# Altium schematic sheet USB to RS485 ISO - ISO3088.SchDoc("USB to RS485 ISO - ISO3088")
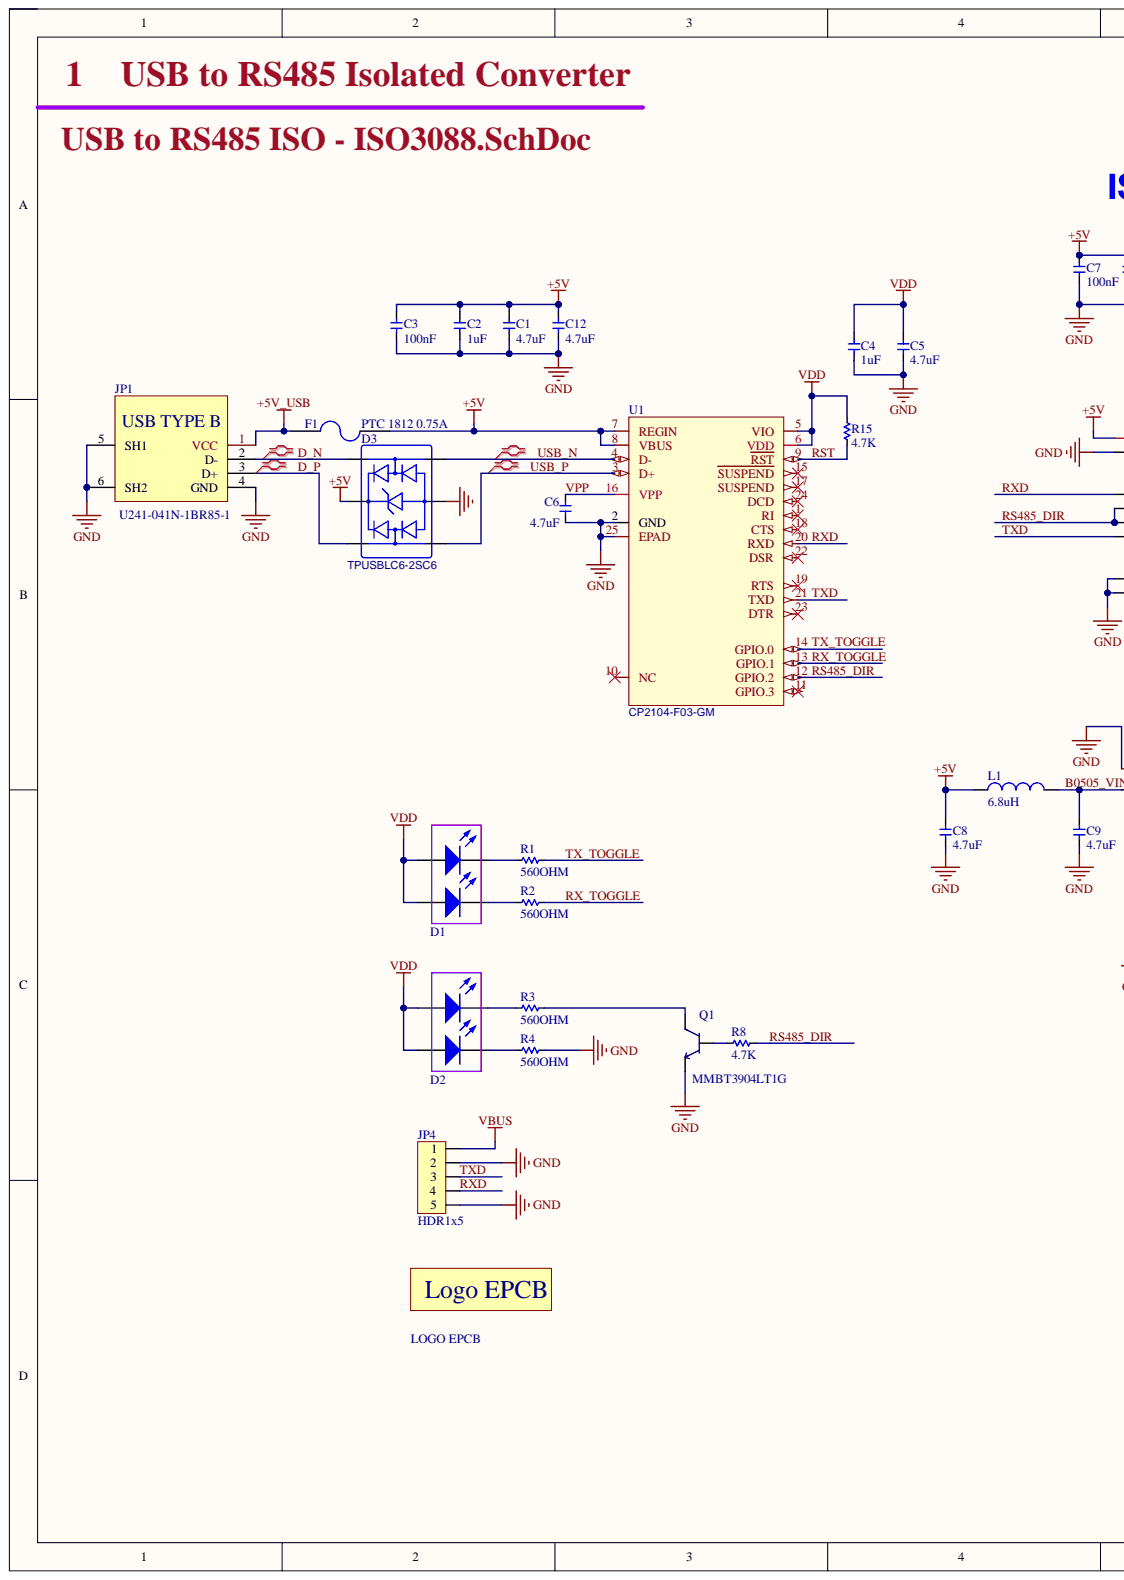
[diagram p0: USB to RS485 ISO - ISO3088.SchDoc, left]
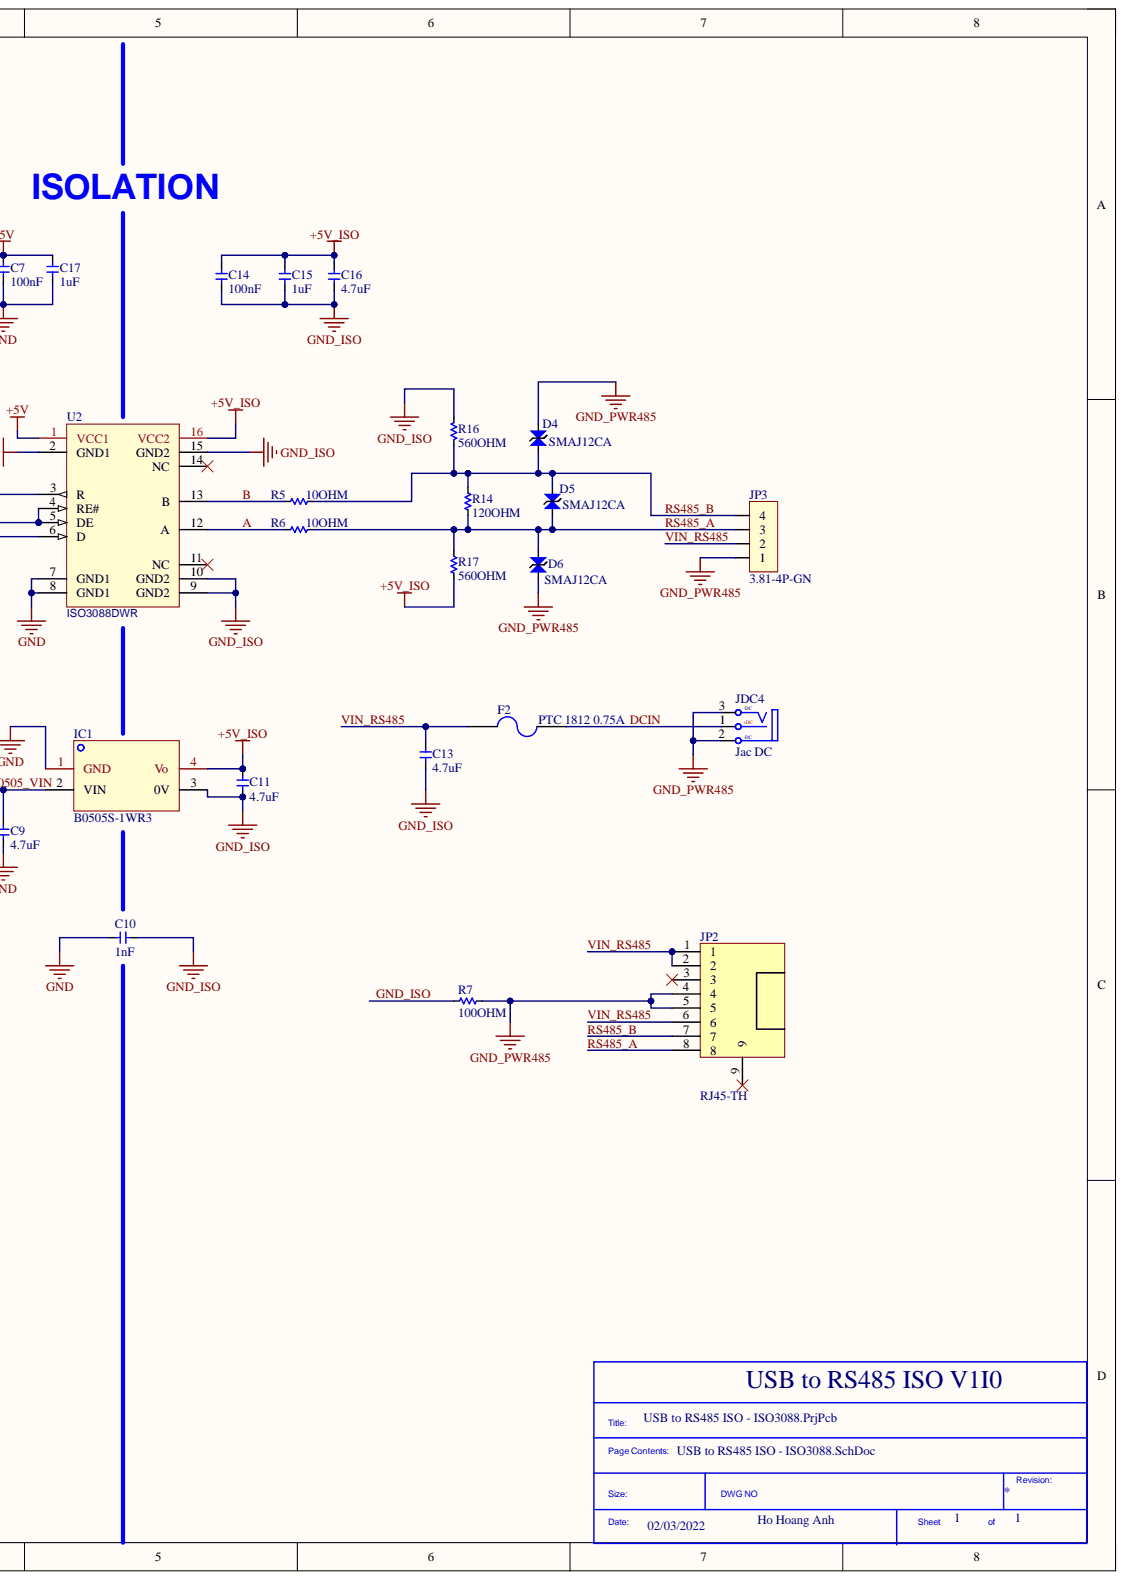
[diagram p1: USB to RS485 ISO - ISO3088.SchDoc, right]

## Parts
C1  4.7uF  [C_1206]
C2  1uF  [C_0603]
C3  100nF  [C_0603]
C4  1uF  [C_0603]
C5  4.7uF  [C_1206]
C6  4.7uF  [C_0603]
C7  100nF  [C_0603]
C8  4.7uF  [C_1206]
C9  4.7uF  [C_1206]
C10  1nF  [C_1206]
C11  4.7uF  [C_1206]
C12  4.7uF  [C_1206]
C13  4.7uF  [C_1206]
C14  100nF  [C_0603]
C15  1uF  [C_0603]
C16  4.7uF  [C_1206]
C17  1uF  [C_0603]
D1  2LED_3MM  [2LED_3MM]  (value not drawn)
D2  2LED_3MM  [2LED_3MM]  (value not drawn)
D3  TPUSBLC6-2SC6  [SOT-23-6_L2.9-W1.6-P0.95-LS2.8-BR]
D4  SMAJ12CA  [SMA_L4.4-W2.6-LS5.0-BI]
D5  SMAJ12CA  [SMA_L4.4-W2.6-LS5.0-BI]
D6  SMAJ12CA  [SMA_L4.4-W2.6-LS5.0-BI]
F1  PTC 1812 0.75A  Package: 1812  [F_1812]
F2  PTC 1812 0.75A  Package: 1812  [F_1812]
IC1  B0505S-1WR3  [PWRM-TH_BXXXXS-1WR3]
JDC4  Jac DC  [DC0]
JP1  U241-041N-1BR85-1  [USB-B-TH_U241-041N-1BR85-1]
JP2  RJ45-TH  [RJ45R10P-B000]
JP3  3.81-4P-GN  [Terminal plug 3.81_4P]
JP4  HDR1x5  [HDR1X5]  (no BOM)
L1  6.8uH  [IND-SMD_L3.0-W3.0]
LOGO_EPCB  LOGO EPCB  [LOGO EPCB]  (no BOM)
Q1  MMBT3904LT1G  [SOT-23-3]
R1  560OHM  [R_0603]
R2  560OHM  [R_0603]
R3  560OHM  [R_0603]
R4  560OHM  [R_0603]
R5  10OHM  [R_0805]
R6  10OHM  [R_0805]
R7  100OHM  [R_0805]
R8  4.7K  [R_0603]
R14  120OHM  [R_0603]
R15  4.7K  [R_0603]
R16  560OHM  [R_0603]
R17  560OHM  [R_0603]
U1  CP2104-F03-GM  [QFN-24_L4.0-W4.0-P0.50-BL-EP2.8]
U2  ISO3088DWR  [SOIC-16_L10.3-W7.5-P1.27-LS10.3-BL]

## Nets
+5V: C1.2 C2.2 C3.2 C7.2 C8.2 C12.2 C17.2 D3.5 F1.2 L1.1 U1.7(REGIN) U1.8(VBUS) U2.1(VCC1)
+5V_ISO: C11.2 C14.2 C15.2 C16.2 IC1.4(Vo) R17.2 U2.16(VCC2)
+5V_USB: F1.1 JP1.1(VCC)
GND: C1.1 C2.1 C3.1 C4.1 C5.1 C6.1 C7.1 C8.1 C9.1 C10.1 C12.1 C17.1 D3.2 IC1.1(GND) JP1.4(GND) JP1.5(SH1) JP1.6(SH2) JP4.2 JP4.5 Q1.2 R4.1 U1.2(GND) U1.25(EPAD) U2.2(GND1) U2.7(GND1) U2.8(GND1)
GND_PWR485: D4.1 D6.2 JDC4.2 JDC4.3 JP2.4 JP2.5 JP3.1 R7.1
VBUS: JP4.1
VDD: C4.2 C5.2 D1.1 D1.3 D2.1 D2.3 R15.1 U1.5(VIO) U1.6(VDD)
A: R6.2 U2.12(A)
B: R5.2 U2.13(B)
B0505_VIN: C9.2 IC1.2(VIN) L1.2
D_N: D3.6 JP1.2(D-)
D_P: D3.4 JP1.3(D+)
DCIN: F2.2 JDC4.1
GND_ISO: C10.2 C11.1 C13.1 C14.1 C15.1 C16.1 IC1.3(0V) R7.2 R16.1 U2.9(GND2) U2.10(GND2) U2.15(GND2)
RS485_A: D5.2 D6.1 JP2.8 JP3.3 R6.1 R14.2 R17.1
RS485_B: D4.2 D5.1 JP2.7 JP3.4 R5.1 R14.1 R16.2
RS485_DIR: R8.2 U1.12(GPIO.2) U2.4(RE#) U2.5(DE)
RST: R15.2 U1.9(RST)
RX_TOGGLE: R2.1 U1.13(GPIO.1)
RXD: JP4.4 U1.20(RXD) U2.3(R)
TX_TOGGLE: R1.1 U1.14(GPIO.0)
TXD: JP4.3 U1.21(TXD) U2.6(D)
USB_N: D3.1 U1.4(D-)
USB_P: D3.3 U1.3(D+)
VIN_RS485: C13.2 F2.1 JP2.1 JP2.2 JP2.6 JP3.2
VPP: C6.2 U1.16(VPP)
NetD1_2: D1.2 R2.2
NetD1_4: D1.4 R1.2
NetD2_2: D2.2 R4.2
NetD2_4: D2.4 R3.2
NetQ1_1: Q1.1 R8.1
NetQ1_3: Q1.3 R3.1

Not connected: JP2 3 9; U1 1(RI) 10(NC) 11(GPIO.3) 15(SUSPEND) 17(SUSPEND) 18(CTS) 19(RTS) 22(DSR) 23(DTR) 24(DCD); U2 11(NC) 14(NC)

## Library data of the parts
C1 C5 C8 C9 C11-C13 C16 = 1206B475K500NT: 4.7uF 50V X7R ±10% 1206 Multilayer Ceramic Capacitors MLCC - SMD/SMT ROHS; Manufacturer: FH(Guangdong Fenghua Advanced Tech); SMT Assembly: Yes; Component Kind: Standard; LCSC Part Number: C29823; Library Name: USB to RS485 ISO - ISO3088.SCHLIB; Manufacturer Part Number: 1206B475K500NT; Package: 1206
C2 C4 C15 C17 = CL10A105KB8NNNC: 1UF 50V 0603 ROHS; Manufacturer: Samsung Electro-Mechanics; SMT Assembly: Yes; Component Kind: Standard; ComponentLink1Description: Digikey Link; ComponentLink1URL: https://www.digikey.com/en/products/detail/samsung-electro-mechanics/CL10A105KB8NNNC/3887518?s=N4IgTCBcDaIMIBkCMAGAgqgrAaQEIA4A5YuEAXQF8g; ComponentLink2Description: LCSC Link; ComponentLink2URL: https://jlcpcb.com/parts/componentSearch?searchTxt=10k%200603; Digikey Part Number: 1276-1860-2-ND; LCSC Part Number: C15849; Library Name: USB to RS485 ISO - ISO3088.SCHLIB; Manufacturer Part Number: CL10A105KB8NNNC; Package: 0603; Pricing 1: 50=0.01000, 500=0.0079 (LCSC)
C3 C7 C14 = CC0603KRX7R9BB104: 100nF 50V X7R ±10% 0603 Multilayer Ceramic Capacitors MLCC - SMD/SMT ROHS; Manufacturer: YAGEO; SMT Assembly: Yes; Component Kind: Standard; LCSC Part Number: C14663; Library Name: USB to RS485 ISO - ISO3088.SCHLIB; Manufacturer Part Number: CC0603KRX7R9BB104; Package: 0603
C6 = CL10A475KO8NNNC: 4.7UF 16V 0603 ROHS; Manufacturer: Samsung Electro-Mechanics; SMT Assembly: Yes; Component Kind: Standard; LCSC Part Number: C19666; Library Name: USB to RS485 ISO - ISO3088.SCHLIB; Manufacturer Part Number: CL10A475KO8NNNC; Package: 0603; Pricing 1: 50=0.01000, 500=0.0079 (LCSC)
C10 = CC1206JKNPOCBN102: 1nF ±5% 1kV NP0 1206 Multilayer Ceramic Capacitors MLCC - SMD/SMT RoHS; Manufacturer: YAGEO; SMT Assembly: Yes; Component Kind: Standard; ComponentLink1Description: Digikey Link; ComponentLink1URL: https://www.digikey.com/en/products/detail/yageo/CC0603KRX7R9BB104/2103082?s=N4IgTCBcDaIMwEYEFoFwCzuWZA5AIiALoC%2BQA; ComponentLink2Description: LCSC Link; ComponentLink2URL: https://jlcpcb.com/parts/componentSearch?searchTxt=10k%200603; LCSC Part Number: C113872; Library Name: USB to RS485 ISO - ISO3088.SCHLIB; Manufacturer Part Number: CC1206JKNPOCBN102; Package: 1206
D1 D2 = 2LED3MM: LED DUAL RED/GREEN DIFFUSED T/H; Manufacturer: Lumex; Manufacturer Part Number: SSF-LXH240IGD; SMT Assembly: No; Component Kind: Standard; ComponentLink1Description: https://datasheet.ciiva.com/16387/lumex20ssf-lxh240igd-16387412.pdf?src-supplier=Future+Electronics; ComponentLink1URL: https://datasheet.ciiva.com/16387/lumex20ssf-lxh240igd-16387412.pdf?src-supplier=Future+Electronics; ComponentLink2Description: https://datasheet.ciiva.com/4673/ssf-lxh240igd-59870-4673513.pdf?src-supplier=Mouser; ComponentLink2URL: https://datasheet.ciiva.com/4673/ssf-lxh240igd-59870-4673513.pdf?src-supplier=Mouser; ComponentLink3Description: https://datasheet.ciiva.com/14605/70127278-14605558.pdf?src-supplier=Allied+Electronics; ComponentLink3URL: https://datasheet.ciiva.com/14605/70127278-14605558.pdf?src-supplier=Allied+Electronics; ComponentLink4Description: https://datasheet.ciiva.com/16840/70127280-16840571.pdf?src-supplier=Allied+Electronics; ComponentLink4URL: https://datasheet.ciiva.com/16840/70127280-16840571.pdf?src-supplier=Allied+Electronics; ComponentLink5Description: https://datasheet.ciiva.com/1535/ssf-lxh240igd-1535964.pdf?src-supplier=Element14; ComponentLink5URL: https://datasheet.ciiva.com/1535/ssf-lxh240igd-1535964.pdf?src-supplier=Element14; ComponentLink6Description: https://datasheet.ciiva.com/1644/ssf-lxh240igd-1644716.pdf?src-supplier=Farnell; ComponentLink6URL: https://datasheet.ciiva.com/1644/ssf-lxh240igd-1644716.pdf?src-supplier=Farnell; ComponentLink7Description: https://datasheet.ciiva.com/1536/ssf-lxh240igd-1536046.pdf?src-supplier=Element14; ComponentLink7URL: https://datasheet.ciiva.com/1536/ssf-lxh240igd-1536046.pdf?src-supplier=Element14; ComponentLink8Description: https://datasheet.ciiva.com/1746/ssf-lxh240igd-1746846.pdf?src-supplier=Newark; ComponentLink8URL: https://datasheet.ciiva.com/1746/ssf-lxh240igd-1746846.pdf?src-supplier=Newark; ComponentLink9Description: http://datasheet.octopart.com/SSF-LXH240IGD-Lumex-datasheet-60839.pdf?src-supplier=Element14; ComponentLink9URL: http://datasheet.octopart.com/SSF-LXH240IGD-Lumex-datasheet-60839.pdf?src-supplier=Element14; ComponentLink10Description: http://datasheet.octopart.com/SSF-LXH240IGD-Lumex-datasheet-9743625.pdf?src-supplier=Allied+Electronics; ComponentLink10URL: http://datasheet.octopart.com/SSF-LXH240IGD-Lumex-datasheet-9743625.pdf?src-supplier=Allied+Electronics; LCSC Part Number: No; Library Name: USB to RS485 ISO V1I0.SCHLIB; Package: Through Hole
D3 = ESD_TPUSBLC6-2SC6: ESD ROHS SOT23-6 DIODES; Manufacturer: TECH PUBLIC; BOM_Assembly Type: smtWeld; BOM_Paste Type: expand; SMT Assembly: Yes; SMT Type: Extend; BOM_Supplier: LCSC; Component Kind: Standard; LCSC Part Number: C558442; Library Name: USB to RS485 ISO V1I0.SCHLIB; Manufacturer Part Number: TPUSBLC6-2SC6; Package: SOT-23-6; spicePre: D; spiceSymbolName: TPUSBLC6-2SC6
D4-D6 = SMAJ12CA: TVS DIODE 12V 19.9V SMA; Manufacturer: MDD（Microdiode Electronics）; SMT Assembly: Yes; Component Kind: Standard; LCSC Part Number: C110042; Library Name: USB to RS485 ISO - ISO3088.SCHLIB; Manufacturer Part Number: SMAJ12CA; Package: SMA,DO-214AC
F1 F2 = PTC_mSMD075-33V: 1812 PTC RESETTABLE FUSES ROHS 24V; Manufacturer: TECHFUSE; SMT Assembly: Yes; Component Kind: Standard; LCSC Part Number: C70117; Library Name: USB to RS485 ISO - ISO3088.SCHLIB; Manufacturer Part Number: mSMD075-33V; Pricing 1: 1=0.08630,10=0.04930 (LCSC)
IC1 = B0505S-1WR3: B0505S-1WR3 5V 200mA 5.5V 1 Plugin Power Modules ROHS; Manufacturer: MORNSUN Guangzhou S& T; Manual Assembly: Yes; SMT Assembly: No; Component Kind: Standard; Digikey Part Number: 2725-B0505S-1WR3-ND; LCSC Part Number: C131038; Library Name: USB to RS485 ISO - ISO3088.SCHLIB; Manufacturer 2: Mornsun America, LLC; Manufacturer Part Number: B0505S-1WR3; Manufacturer Part Number 2: B0505S-1WR3; Package: SIP-4
JDC4 = DCIN_1: Jac DC input 5.5x2.1MM; Manufacturer: JINJIA; Manufacturer Part Number: DC+02I; SMT Assembly: No; Component Kind: Standard; LCSC Part Number: C718033; Library Name: USB to RS485 ISO V1I0.SCHLIB; Package: Through Hole
JP1 = USB_TYPE_B_U241: USB - Type B Female USB 2.0 4 Through Hole USB Connectors RoHS; Manufacturer: XKB Connectivity; SMT Assembly: No; Component Kind: Standard; LCSC Part Number: C397338; Library Name: USB to RS485 ISO V1I0.SCHLIB; Manufacturer Part Number: U241-041N-1BR85-1; Package: Through Hole
JP2 = RJ45R10P-B000: RJ45 18MM, No Transformer; Manufacturer: Ckmtw(Shenzhen Cankemeng); Manufacturer Part Number: R-RJ45R10P-B000; SMT Assembly: No; Component Kind: Standard; LCSC Part Number: C386758; Library Name: USB to RS485 ISO V1I0.SCHLIB; Package: RJ45-TH_R-RJ45R10P-B000
JP3 = CONN_DB2ERM-3.81-4P-GN: 4P Connector Through Hole Pluggable System Terminal Block RoHS; Manufacturer: DIBO; SMT Assembly: No; Component Kind: Standard; LCSC Part Number: No; Library Name: USB to RS485 ISO V1I0.SCHLIB; Manufacturer Part Number: DB2ERM-3.81-4P-GN; Package: Through Hole
JP4 = Header5: Header IDC, 5 x 1 Pin Vertical 2.54mm Pitch (Non-Boxed, Male); Manufacturer: No; ComponentLink1 Description: Manufacturer; ComponentLink2 Description: Datasheet; SMT Assembly: No; ComponentLink1URL: http://www.we-online.com; ComponentLink2URL: http://katalog.we-online.de/em/datasheet/6130xx143121.pdf; LCSC Part Number: No; Library Name: USB to RS485 ISO V1I0.SCHLIB; Manufacturer Part Number: No; Package: Through hole
L1 = IND_SWP_6R8: 6.8UH ±20% 980MA 247MΩ SMD,3.0X3.0X1.2MM POWER INDUCTORS ROHS; Manufacturer: Sunlord; Manufacturer Part Number: SWPA3012S6R8MT; SMT Assembly: Yes; Component Kind: Standard; LCSC Part Number: C83416; Library Name: USB to RS485 ISO - ISO3088.SCHLIB; Package: SMD,3.0x3.0x1.2mm
Q1 = MMBT3904LT1G: MMBT3904 TRANSISTORS (NPN/PNP) NPN 200MA 40V SOT-23(SOT-23-3) ROHS; Manufacturer: Onsemi; SMT Assembly: Yes; Component Kind: Standard; LCSC Part Number: C81464; Library Name: USB to RS485 ISO - ISO3088.SCHLIB; Manufacturer Part Number: MMBT3904LT1G; Package: SOT-23-3
R1-R4 R16 R17 = 0603WAF5600T5E: 560OHMS ±1% 1/10W 0603 ROHS; Manufacturer: Uniroyal Elec; SMT Assembly: Yes; Component Kind: Standard; ComponentLink1Description: https://datasheet.ciiva.com/7746/443971-7746518.pdf?src-supplier=Element14; ComponentLink1URL: https://datasheet.ciiva.com/7746/443971-7746518.pdf?src-supplier=Element14; ComponentLink2Description: https://datasheet.ciiva.com/2452/pyu-r-int-thick-7-2452887.pdf?src-supplier=Digi-Key; ComponentLink2URL: https://datasheet.ciiva.com/2452/pyu-r-int-thick-7-2452887.pdf?src-supplier=Digi-Key; ComponentLink3Description: https://datasheet.ciiva.com/12558/rc0603-272842-12558414.pdf?src-supplier=Mouser; ComponentLink3URL: https://datasheet.ciiva.com/12558/rc0603-272842-12558414.pdf?src-supplier=Mouser; ComponentLink4Description: https://datasheet.ciiva.com/7746/443971-7746517.pdf?src-supplier=Element14; ComponentLink4URL: https://datasheet.ciiva.com/7746/443971-7746517.pdf?src-supplier=Element14; ComponentLink5Description: https://datasheet.ciiva.com/2452/pyu-r-int-thick-7-2452888.pdf?src-supplier=Digi-Key; ComponentLink5URL: https://datasheet.ciiva.com/2452/pyu-r-int-thick-7-2452888.pdf?src-supplier=Digi-Key; ComponentLink6Description: https://datasheet.ciiva.com/2452/pyu-r-int-thick-7-2452889.pdf?src-supplier=Digi-Key; ComponentLink6URL: https://datasheet.ciiva.com/2452/pyu-r-int-thick-7-2452889.pdf?src-supplier=Digi-Key; LCSC Part Number: C23204; Library Name: USB to RS485 ISO - ISO3088.SCHLIB; Manufacturer Part Number: 0603WAF5600T5E; Package: 0603
R5 R6 = 0805W8F100JT5E: 10OHMS ±1% 1/8W 0805 ROHS; Manufacturer: Uniroyal Elec; SMT Assembly: Yes; Component Kind: Standard; LCSC Part Number: C17415; Library Name: USB to RS485 ISO - ISO3088.SCHLIB; Manufacturer Part Number: 0805W8F100JT5E; Package: 0805
R7 = 0805W8F1000T5E: 100OHMS ±1% 1/8W 0805 ROHS; Manufacturer: Uniroyal Elec; SMT Assembly: Yes; Component Kind: Standard; LCSC Part Number: C17408; Library Name: USB to RS485 ISO - ISO3088.SCHLIB; Manufacturer Part Number: 0805W8F1000T5E; Package: 0805
R8 R15 = 0603WAF4701T5E: 4.7KOHMS ±1% 1/10W 0603 ROHS; Manufacturer: Uniroyal Elec; SMT Assembly: Yes; Component Kind: Standard; LCSC Part Number: C23162; Library Name: USB to RS485 ISO - ISO3088.SCHLIB; Manufacturer Part Number: 0603WAF4701T5E; Package: 0603; Pricing 1: 1=0.00160, 10=0.00160, 100=0.00160, 1000=0.00120 (LCSC)
R14 = 0603WAF1200T5E: 120OHMS ±1% 1/10W 0603 ROHS; Manufacturer: Uniroyal Elec; SMT Assembly: Yes; Component Kind: Standard; LCSC Part Number: C22787; Library Name: USB to RS485 ISO - ISO3088.SCHLIB; Manufacturer Part Number: 0603WAF1200T5E; Package: 0603; Pricing 1: 1=0.0020, 10=0.0020, 100=0.0020, 1000=0.00150 (LCSC)
U1 = CP2104-F03-GM: CP2104 USB 1/1 2MBPS 3V ~ 3.6V QFN-24_4X4X05P ROHS; Manufacturer: SILICON LABS; SMT Assembly: Yes; Component Kind: Standard; LCSC Part Number: C47742; Library Name: USB to RS485 ISO V1I0.SCHLIB; Manufacturer Part Number: CP2104-F03-GM; Package: C47742
U2 = ISO3088DWR: 20Mbps RS422, RS485 Transceiver SOIC-16_300mil RS-485/RS-422 ICs ROHS; Manufacturer: Texas Instruments; SMT Assembly: Yes; Component Kind: Standard; LCSC Part Number: C39386; Library Name: USB to RS485 ISO - ISO3088.SCHLIB; Manufacturer Part Number: ISO3088DWR; Package: SOIC-16_7.5x10.3x1.27P
LOGO_EPCB = LOGO EPCB: Description: LOGO EPCB; Library Name: USB to RS485 ISO V1I0.SCHLIB
